# Altium schematic sheet isg_eos_control_board_xem3001.SchDoc (continued)

## Library data of the parts
C10 C13 C14 C17-C19 = CMP-03422-000030-1: Manufacturer: YAGEO; Manufacturer Part Number: CC0603KRX7R7BB104; Capacitance: 100 nF; Material: Ceramic; Temperature Characteristic: X7R; Temperature Coefficient: 15%; Tolerance: 0.1; Value: 100 nF; Voltage: 16 V; Automotive: No; Category: Cap; Component Kind: Standard; ComponentLink2Description: Datasheet; ComponentLink2URL: http://www.yageo.com/documents/recent/UPY-GPHC_X7R_6.3V-to-50V_18.pdf; Device Class L1: Passive Components; Device Class L2: Capacitors; Device Class L3: Ceramic Capacitors; Digikey Description: CAP CER 0.1UF 16V X7R 0603; Footprint Url: http://www.yageo.com/exep/pages/download/literatures/UPY-C_GEN_24.pdf; Height: 0.9mm; Lead Free: Yes; Library Name: Altium Content Vault; Mouser Description: Multilayer Ceramic Capacitor, CC Series, 0.1 - F, - 10%, X7R, 16 V, 0603 [1608 Metric]; Octopart: https://octopart.com/cc0603krx7r7bb104-yageo-192941; Package: CC0603-DA; RoHS: TRUE; Temperature: -55°C to +125°C; Temperature Range High: +125°C; Temperature Range Low: -55°C; Voltage Rating: 16 V
C11 C15 C20 C22-C24 = CMP-03422-000030-1: Manufacturer: YAGEO; Manufacturer Part Number: CC0603KRX7R7BB104; Capacitance: 100 nF; Material: Ceramic; Temperature Coefficient: 15%; Tolerance: 0.1; Value: 100 nF; Voltage: 16 V; Automotive: No; Category: Cap; Component Kind: Standard; ComponentLink2Description: Datasheet; ComponentLink2URL: http://www.yageo.com/documents/recent/UPY-GPHC_X7R_6.3V-to-50V_18.pdf; Device Class L1: Passive Components; Device Class L2: Capacitors; Device Class L3: Ceramic Capacitors; Digikey Description: CAP CER 0.1UF 16V X7R 0603; Footprint Url: http://www.yageo.com/exep/pages/download/literatures/UPY-C_GEN_24.pdf; Height: 0.9mm; Lead Free: Yes; Library Name: Altium Content Vault; Mouser Description: Multilayer Ceramic Capacitor, CC Series, 0.1 - F, - 10%, X7R, 16 V, 0603 [1608 Metric]; Octopart: https://octopart.com/cc0603krx7r7bb104-yageo-192941; Package: CC0603-DA; RoHS: TRUE; Temperature: -55°C to +125°C; Temperature Characteristic: X7R; Temperature Range High: +125°C; Temperature Range Low: -55°C; Voltage Rating: 16 V
C12 C16 C21 C25 = CMP-2007-04677-1: Manufacturer: AVX Interconnect / Elco; Part Number: 0805ZC475KAT2A; Component Kind: Standard; Library Name: Altium Content Vault
D1 = CMP-2000-05896-2: DIODE SCHOTTKY 30V 3A SMA; Manufacturer: Diodes; Automotive Grade: Grade 0; Capacitance: 200pF; Max Junction Temp: +150°C; Max Surge Forward Current: 80A; Peak Reverse Current: 0.5mA; Standoff Height: 0.05mm; Value: 200pF; Automotive: Yes; Category: Diode; Component Kind: Standard; ComponentLink2Description: Datasheet; ComponentLink2URL: https://www.diodes.com/assets/Datasheets/ds30891.pdf; Dc Blocking Voltage: 30V; Device Class L1: Discrete Semiconductors; Device Class L2: Diodes; Device Class L3: Schottky Diodes; Digikey Description: DIODE SCHOTTKY 30V 3A SMA; Footprint Url: https://www.diodes.com/assets/Package-Files/SMA.pdf; Forward Current: 3A; Height: 2.4mm; Lead Free: Yes; Library Name: Altium Content Vault; Manufacturer Part Number: B330A-13-F; Max Repetitive Reverse Voltage: 30V; Mouser Description: Schottky Diodes & Rectifiers 30V 3A; Octopart: https://octopart.com/b330a-13-f-diodes+inc.-325808; Package: SMA; Reverse Voltage: 30V; RoHS: TRUE; Temperature: -55°C to +150°C; Temperature Range High: +150°C; Temperature Range Low: -55°C; Working Reverse Voltage: 30V
D2 = CMP-12225-000045-1: TVS DIODE 12V 25.3V SMA; Manufacturer: STMicroelectronics; Breakdown Voltage: 13.3V; Clamping Voltage: 19.9V; Direction: Bidirectional; Max Junction Temp: +150°C; Number Of Channels: 1; Peak Pulse Current: 20.1A; Peak Pulse Power: 400W; Standoff Height: 0.05mm; Automotive: No; Category: Diode; Component Kind: Standard; ComponentLink2Description: Datasheet; ComponentLink2URL: https://www.st.com/resource/en/datasheet/smaj.pdf; Device Class L1: Circuit Protection; Device Class L2: TVS Diodes; Device Class L3: unset; Digikey Description: TVS DIODE 12V 25.3V SMA; Height: 2.65mm; Lead Free: Yes; Library Name: Altium Content Vault; Manufacturer Part Number: SMAJ12CA-TR; Mouser Description: TVS Diodes - Transient Voltage Suppressors 400W 12V Bidirect; Octopart: https://octopart.com/smaj12ca-tr-stmicroelectronics-347060; Package: SMA-CA; RoHS: TRUE; Temperature: -55°C to +150°C; Temperature Range High: +150°C; Temperature Range Low: -55°C
DS1-DS4 = CMP-2000-05062-1: Chip LED, Green, 2.2 V, -40 to 85 degC, 2-Pin SMD, Pb-Free, Tape and Reel; Manufacturer: Avago; Bulb Size: 1.25mmx1.4mm; Dominant Wavelength: 572nm; External Depth: 0.8mm; External Length / Height: 2mm; External Width: 1.25mm; Forward Current If: 20mA; Forward Voltage: 2.2V; Forward Voltage VF Max: 2.6V; LED Type: Standard; Lens Shape: Rectangular; Light Area Height: 1mm; Luminous Intensity: 15mcd; Luminous Intensity Min: 4mcd; No. of Pins: 2; Opto Mounting Orientation: Top; Peak Wavelength: 570nm; PIV Max: 5V; SVHC: NoSVHC(16-Jun-2014); Viewing Angle: 170deg; Component Kind: Standard; Datasheet URL: https://datasheet.ciiva.com/29280/180495-da-01-en-chip-led-hsmg-c170-gelb-gruen-29280971.pdf; Datasheet Version: Oct-08; Dominant Wavelength Typ: 572nm; Forward Current If Max: 20mA; LED Colour: Green; LED Mounting: SMD; Library Name: Altium Content Vault; Luminous Intensity Typ: 15mcd; Manufacturer URL: http://www.avagotech.com/; Mounting Type: SMD; MSL: MSL2A-4weeks; Operating Temperature Max: 85degC; Operating Temperature Min: -30degC; Operating Temperature Range: -30degCto+85degC; Opto Case Style: SMD; Package Description: 2-Pin Surface Mount Device, Body 2 x 1.25 mm, Height 0.8 mm; Package Reference: HSMX-C170; Packaging: CutTape; Peak Forward Current: 20mA; Storage Temperature Max: 85degC; Storage Temperature Min: -40degC; Voltage Vf Typ: 2.2V; Wavelength Typ: 570nm
J1 = CMP-12400-000001-1: RECEPTACLE, 20A, 4MM, PCB, SOLDER, RED; Manufacturer: Multicomp; Conn Orientation: Horizontal; Current Rating: 24A; Is Female: yes; Number Of Contacts: 1; Voltage Rating: 60V; Automotive: No; Category: Conn; Component Kind: Standard; ComponentLink2Description: Datasheet; ComponentLink2URL: https://datasheet.octopart.com/24.243.1-Multicomp-datasheet-38887736.pdf; Contact Material: Silver; Device Class L1: Connectors; Device Class L2: unset; Device Class L3: unset; Height: 13mm; Lead Free: Yes; Library Name: Altium Content Vault; Manufacturer Part Number: 24.243.1; Octopart: https://octopart.com/24.243.1-multicomp-57101049; Package: 24.243.1; RoHS: TRUE
J2 J4 = CMP-09586-000001-1: CONN TIP JACK SOLDER WHITE; Manufacturer: Cinch Connectivity; Manufacturer Part Number: 105-0751-001; Conn Orientation: Horizontal; Current Rating: 5A; Is Female: yes; Number Of Contacts: 1; Pitch: 10.16mm; Voltage Rating: 1500V; Automotive: No; Category: Conn; Component Kind: Standard; ComponentLink2Description: Datasheet; ComponentLink2URL: https://belfuse.com/resources/Johnson/drawings/dr-1050751001.pdf; Contact Material: Silver; Device Class L1: Connectors; Device Class L2: unset; Device Class L3: unset; Digikey Description: CONN TIP JACK SOLDER WHITE; Height: 0.213inch; Lead Free: Yes; Library Name: Altium Content Vault; Octopart: https://octopart.com/105-0751-001-cinch+connectivity+solutions-51372833; Package: 105-0751-001; RoHS: TRUE; Temperature: -55°C to +75°C; Temperature Range High: +75°C; Temperature Range Low: -55°C
J3 = CMP-12400-000002-1: RECEPTACLE, 20A, 4MM, PCB, SOLDER, BLACK; Manufacturer: Multicomp; Conn Orientation: Horizontal; Current Rating: 24A; Is Female: yes; Number Of Contacts: 1; Voltage Rating: 60V; Automotive: No; Category: Conn; Component Kind: Standard; ComponentLink2Description: Datasheet; ComponentLink2URL: https://datasheet.octopart.com/24.243.2-Multicomp-datasheet-38887736.pdf; Contact Material: Silver; Device Class L1: Connectors; Device Class L2: unset; Device Class L3: unset; Height: 13mm; Lead Free: Yes; Library Name: Altium Content Vault; Manufacturer Part Number: 24.243.2; Octopart: https://octopart.com/24.243.2-multicomp-57101050; Package: 24.243.2; RoHS: TRUE
J6-J13 = CMP-1502-00950-1: THT Vertical Pin Header WR-PHD, Pitch 1.27 mm, Dual Row, 16 pins; Manufacturer: Wurth Elektronik; Contact Resistance: 20mOhm; Gender: Male; Pin Number: 16; Pitch: 1.27mm; Rated Current: 1A; Withstanding Voltage: 500VAC; Working Voltage: 100VAC; Component Kind: Standard; Datasheet URL: https://katalog.we-online.de/em/datasheet/62201621121.pdf; Library Name: Altium Content Vault; Manufacturer URL: http://www.we-online.de; Mounting Technology: THT; Operating Temperature: -40to105degC; Orientation: Vertical; Package Reference: 62201621121; Packaging: Box; Part Number: 62201621121; Row Number: Dual; Publisher: WurthElektronik
J14 = CMP-14830-000005-1: CONN PWR JACK 2X5.5MM SOLDER; Manufacturer: CUI Inc; Conn Gender: Male; Conn Orientation: Right Angle; Current Rating: 2.5A; Number Of Contacts: 3; Shielding: true; Voltage Rating: 24V; Automotive: No; Category: Conn; Component Kind: Standard; ComponentLink2Description: Datasheet; ComponentLink2URL: https://www.cui.com/product/resource/pj-047a.pdf; Contact Material: Tin,Nickel; Device Class L1: Connectors; Device Class L2: Power Connectors; Device Class L3: unset; Digikey Description: CONN PWR JACK 2X5.5MM SOLDER; Height: 9.7mm; Lead Free: Yes; Library Name: Altium Content Vault; Manufacturer Part Number: PJ-047A; Mouser Description: 2.0 x 6.0 mm, 2.5 A, Horizontal, Through Hole, Shielded, Dc Power Jack Connector; Octopart: https://octopart.com/pj-047a-cui-19052783; Package: PJ-047A; RoHS: TRUE; Temperature: -25°C to +85°C; Temperature Range High: +85°C; Temperature Range Low: -25°C
M1 = XEM3001: XEM3001 Module Connector; Manufacturer: Generic Components; Class I: Connector; Component Kind: Standard; Library Name: RAM3001.IntLib; PCB3D: Null3D; Revision: 20040624; Publisher: Opal Kelly
P1 P3-P6 P8 = CMP-1681-00004-4: Male Header, Pitch 2.54 mm, 1 x 2 Position, Height 8.38 mm, Tail Length 2.54 mm, -55 to 125 degC, RoHS, Bulk; Manufacturer: Samtec; Rows: 1; Colour: Black; Component Kind: Standard; ComponentLink1Description: Manufacturer URL; ComponentLink1URL: http://www.samtec.com/; ComponentLink2Description: Datasheet; ComponentLink2URL: http://suddendocs.samtec.com/catalog_english/tsw_th.pdf; Contact Finish: Gold; Contact Material: Bronze; Contact Plating: Gold; DatasheetVersion: 12/2015; Height: 8.38 mm; Lead Pitch: 0.1 inch; Length: 5.08 mm; Library Name: Altium Content Vault; Max Operating Temperature: 125 degC; Min Operating Temperature: -55 degC; Mount: Through Hole; Mounting Technology: Through Hole; Package Quantity: 1; PackageDescription: Male Header, Pitch 2.54 mm, 1 x 2 Position, Height 8.38 mm, Tail Length 2.54 mm; PackageReference: TSW-102-07-S-S; Packaging: Bulk; Pins: 2; REACH SVHC: No SVHC; RoHSCompliant: true
P2 P7 = CMP-1704-00001-3: Male Header, Pitch 2.54 mm, 1 x 2 Position, Height 10.67 mm, Tail Length 3.56 mm, 0 to 75 degC, RoHS, Bulk; Component Kind: Standard; ComponentLink1Description: Manufacturer URL; ComponentLink1URL: http://www.molex.com/; ComponentLink2Description: Datasheet; ComponentLink2URL: https://datasheet.ciiva.com/19454/232266-19454304.pdf; Height: 10.67 mm; Length: 5.08 mm; Library Name: Altium Content Vault; Max Operating Temperature: 75 degC; Min Operating Temperature: 0 degC; Mount: Through Hole; Mounting Technology: Through Hole; Package Quantity: 3000; PackageDescription: Male Header, Pitch 2.54 mm, 1 x 2 Position, Height 10.67 mm, Tail Length 3.56 mm; Packaging: Bulk; PartNumber: 22-23-2021; Pins: 2; Radiation Hardening: No; REACH SVHC: Unknown; RoHSCompliant: True; Width: 6.35 mm
Q1-Q3 = CMP-1258-00134-1: N-Channel Enhancement Mode MOS Transistor, 20 V, 1.05 A, -55 to 150 degC, 3-Pin SOT23, Tape and Reel; Manufacturer: NXP Semiconductors; Channel Type: N; Ciss [typ] (pF): 152; Coss [typ] (pF): 71; ID [max] (A): 1.05; Packing: Tape & Reel; Qr [typ] (nC): 19; VDS [max] (V): 20; VGSth [typ] (V): 0.57; Code_JEDEC: TO-236AB; Component Kind: Standard; ComponentLink1Description: Manufacturer URL; ComponentLink1URL: http://www.nxp.com/; ComponentLink2Description: Datasheet; ComponentLink2URL: http://www.nxp.com/documents/data_sheet/BSH105.pdf; ComponentLink3Description: Package Specification; ComponentLink3URL: http://www.nxp.com/documents/outline_drawing/sot023_po.pdf; DatasheetVersion: Rev. 1.000; Library Name: Altium Content Vault; Mounting Technology: Surface Mount; PackageDescription: 3-Pin Small Outline Transistor Package, Body 2.9 x 1.3 mm, Pitch 0.95 mm; PackageReference: SOT23; PackageVersion: 06-03-16, 03/2006; PartNumber: BSH105,215
R7 R9-R18 R20-R24 = CMP-2000-03820-1: Manufacturer: Vishay Dale; Part Number: CRCW0603100KFKEA; Component Kind: Standard; Library Name: Altium Content Vault
TP3-TP5 = CMP-2000-05181-1: Test Point, Black, 1-Pin THD, RoHS, Bulk; Manufacturer: Keystone; Alternate Color: 5004K-ND  -TESTPOINTPCMINI.040"DYELLOW  5003K-ND  -TESTPOINTPCMINI.040"DORANGE  5002K-ND  -TESTPOINTPCMINI.040"DWHITE  5000K-ND  -TESTPOINTPCMINI.040"DRED; Catalog Drawings: 5000Series; Kits: DKTGRS232C-KIT-ND  -TTLCONVERTER; Online Catalog: ColorCodedTestPoints; Other Names: 5001K; Category: TestandMeasurement; Color: Black; Component Kind: Standard; Datasheet URL: https://ciiva.com/datasheet-click-2917627?src=ciiva&sig=bd195616; Datasheet Version: Rev. G, 12/2008; Family: TestPoints; Library Name: Altium Content Vault; Manufacturer URL: http://www.keyelco.com/; Material: Base,Nylon46,UL94V-0; Mounting Type: 0.040"(1.02mm)HoleDiameter; Packaging: Bulk; RoHS Information: RoHSComplianceStatement; Series: THM; Standard Package: 100; Terminal: 0.010"x0.020"(0.25mmx0.51mm)PhosphorBronzeWire,SilverPlated; Type: PCTestPoint,Miniature
TP6 = CMP-2000-05182-1: Test Point, White, 1-Pin THD, RoHS, Bulk; Brand: KeystoneElectronics; Mounting Hole Size: 1mm; Outside Diameter: 2.54mm; Plating: Silver; Color: White; Component Kind: Standard; Contact Material: PhosphorBronze; Datasheet URL: https://ciiva.com/datasheet-click-2917627?src=ciiva&sig=bd195616; Datasheet Version: Rev. G, 12/2008; Length: 7.62mm; Library Name: Altium Content Vault; Manufacturer: KeystoneElectronics; Manufacturer URL: http://www.keyelco.com/; Material: Nylon; Packaging: Bulk; Product: TestPoints; Product Category: CircuitBoardHardware-PCB; RoHS: True; Type: Miniature
U3 U6 = CMP-0244-00109-1: Fixed Low Drop Positive Voltage Regulator, 5V, 3-Pin DPAK, Tape and Reel; Component Kind: Standard; ComponentLink1Description: Manufacturer URL; ComponentLink1URL: http://www.st.com; ComponentLink2Description: Datasheet; ComponentLink2URL: http://www.st.com/internet/com/TECHNICAL_RESOURCES/TECHNICAL_LITERATURE/DATASHEET/CD00000544.pdf; DatasheetVersion: 1/11/2011; Library Name: Altium Content Vault; PackageDescription: 3-Pin Transistor Outline Package 2.28 mm Pitch, 9.7 mm Lead Span (DPAK); PackageReference: TO-252; PackageVersion: 2011
U4 = CMP-0244-00088-1: Fixed Low Drop Positive Voltage Regulator, 3.3V, 3-Pin DPAK, Tape and Reel; Component Kind: Standard; ComponentLink1Description: Manufacturer URL; ComponentLink1URL: http://www.st.com; ComponentLink2Description: Datasheet; ComponentLink2URL: http://www.st.com/internet/com/TECHNICAL_RESOURCES/TECHNICAL_LITERATURE/DATASHEET/CD00000544.pdf; DatasheetVersion: 1/11/2011; Library Name: Altium Content Vault; PackageDescription: 3-Pin Transistor Outline Package 2.28 mm Pitch, 9.7 mm Lead Span (DPAK); PackageReference: TO-252; PackageVersion: 2011
U5 U7 = CMP-0330-00011-2: Low Input Voltage, 1.8 A Single Channel Load Switch with Controlled Turn-On, 1.8 V Logic Compatible, 1.8 A, 1.4 to 5.5 V, -40 to 85 degC, 6-pin SOT-23 (DBV), Green (RoHS & no Sb/Br); Manufacturer: Texas Instruments; Active High Enable: TRUE; Control Logic: 1.8-V Logic Compatible; HBM ESD(kV): 2; Iin(nA): 10000; Imax(A): 1.8; Output Rise Time(ÂµS): 4500; Quick Output Discharge Transistor: Yes; rON @1.8V(mOhm): 85; SubFamily: Non Current Limited Load Switch; Vin(V): 1.4 - 5.5; Code_JEDEC: MO-178-AB*; Component Kind: Standard; ComponentLink1Description: Datasheet; ComponentLink1URL: http://www.ti.com/general/docs/lit/getliterature.tsp?genericPartNumber=TPS22929D&fileType=pdf; DatasheetVersion: SLVSB39; Eco-Plan: Green (RoHS & no Sb/Br); Library Name: Altium Content Vault; Mounting Technology: Surface Mount; PackageDescription: 6-Pin Plastic Small-Outline Package, 2.9 x 1.6 mm Body, 0.95 mm Pitch, 2.8 mm Lead Span; PackageReference: DBV0006A; PackageVersion: revK, Mar-2006; PartNumber: TPS22929DDBVR
JP1-JP8 = PIN_HEADER_2X8: Component Kind: Standard; Library Name: headers.SchLib
MH1-MH6 = OPTICAL-MOUNT-HOLE-1/4-20: Component Kind: Standard; Library Name: mechanical.SchLib
PCBA1 = ISG-PLL-PCBA: Component Kind: Standard; Library Name: ISG-PLL-PCBA.SchLib
